# Altium schematic sheet power.SchDoc(power)
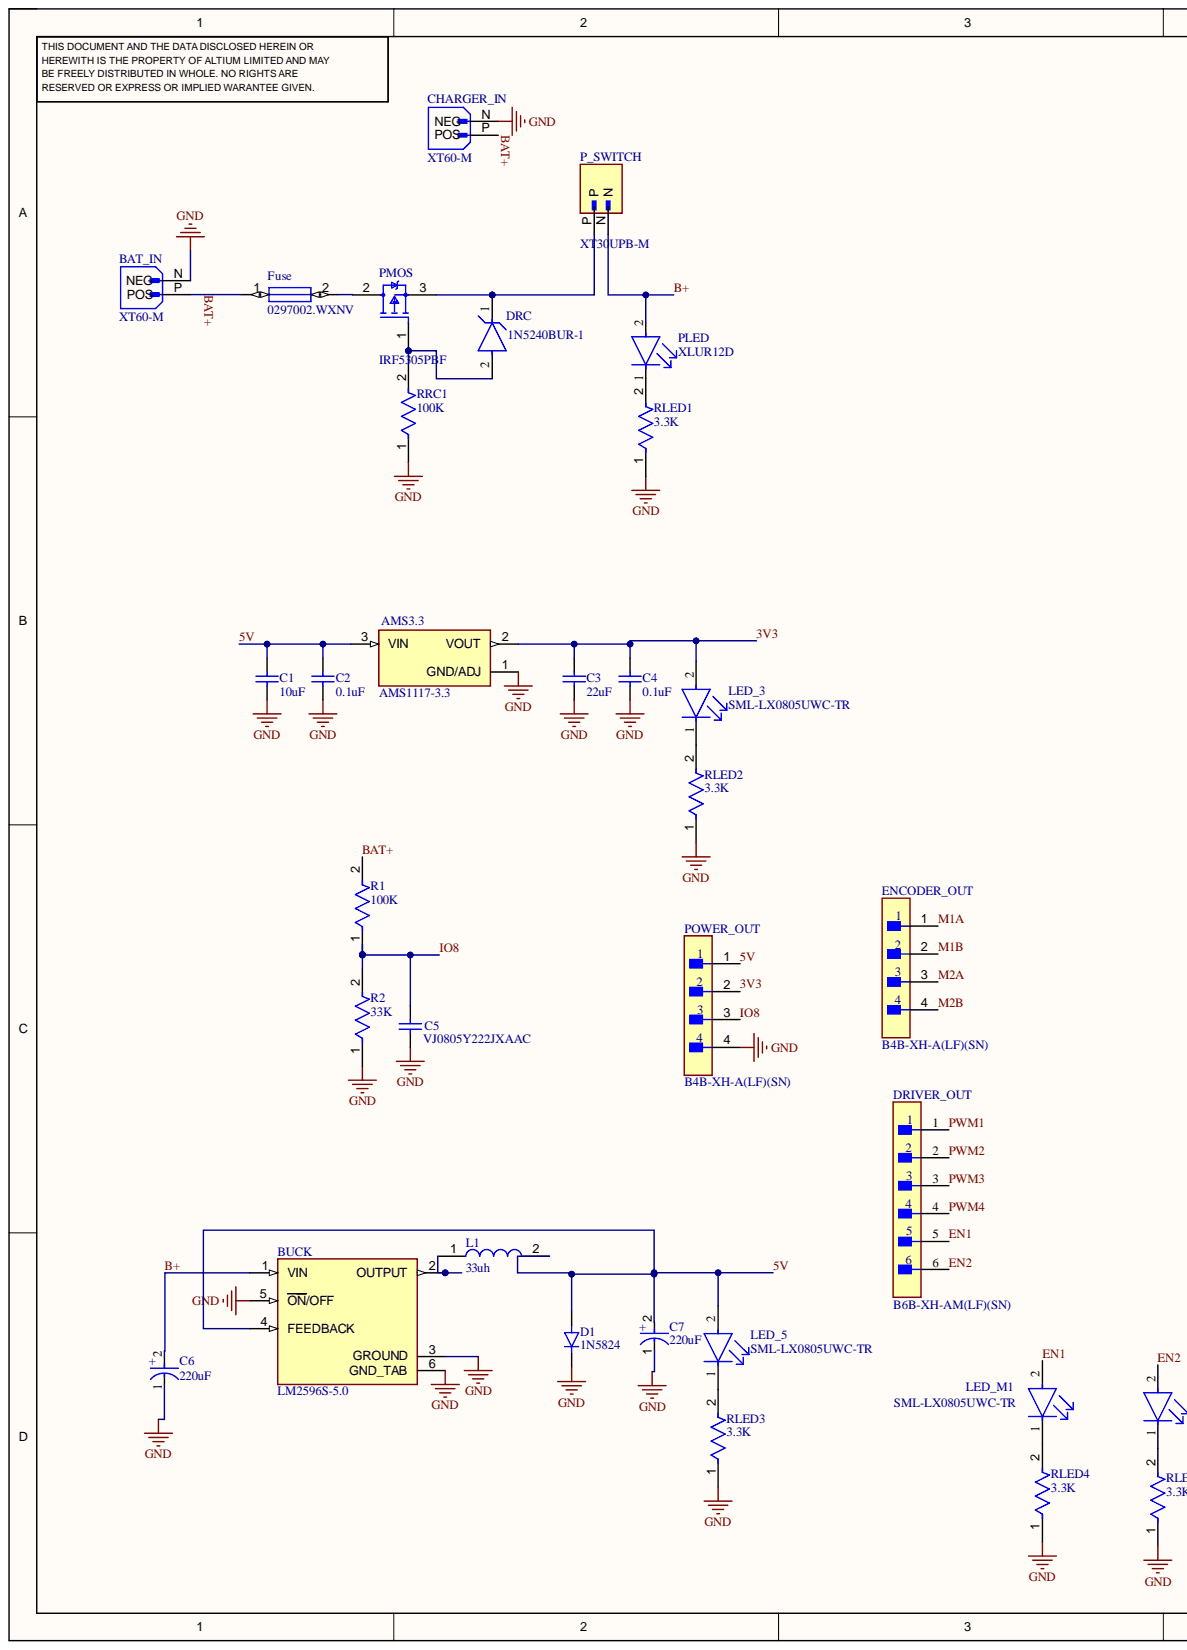
[diagram p0: power.SchDoc, left]
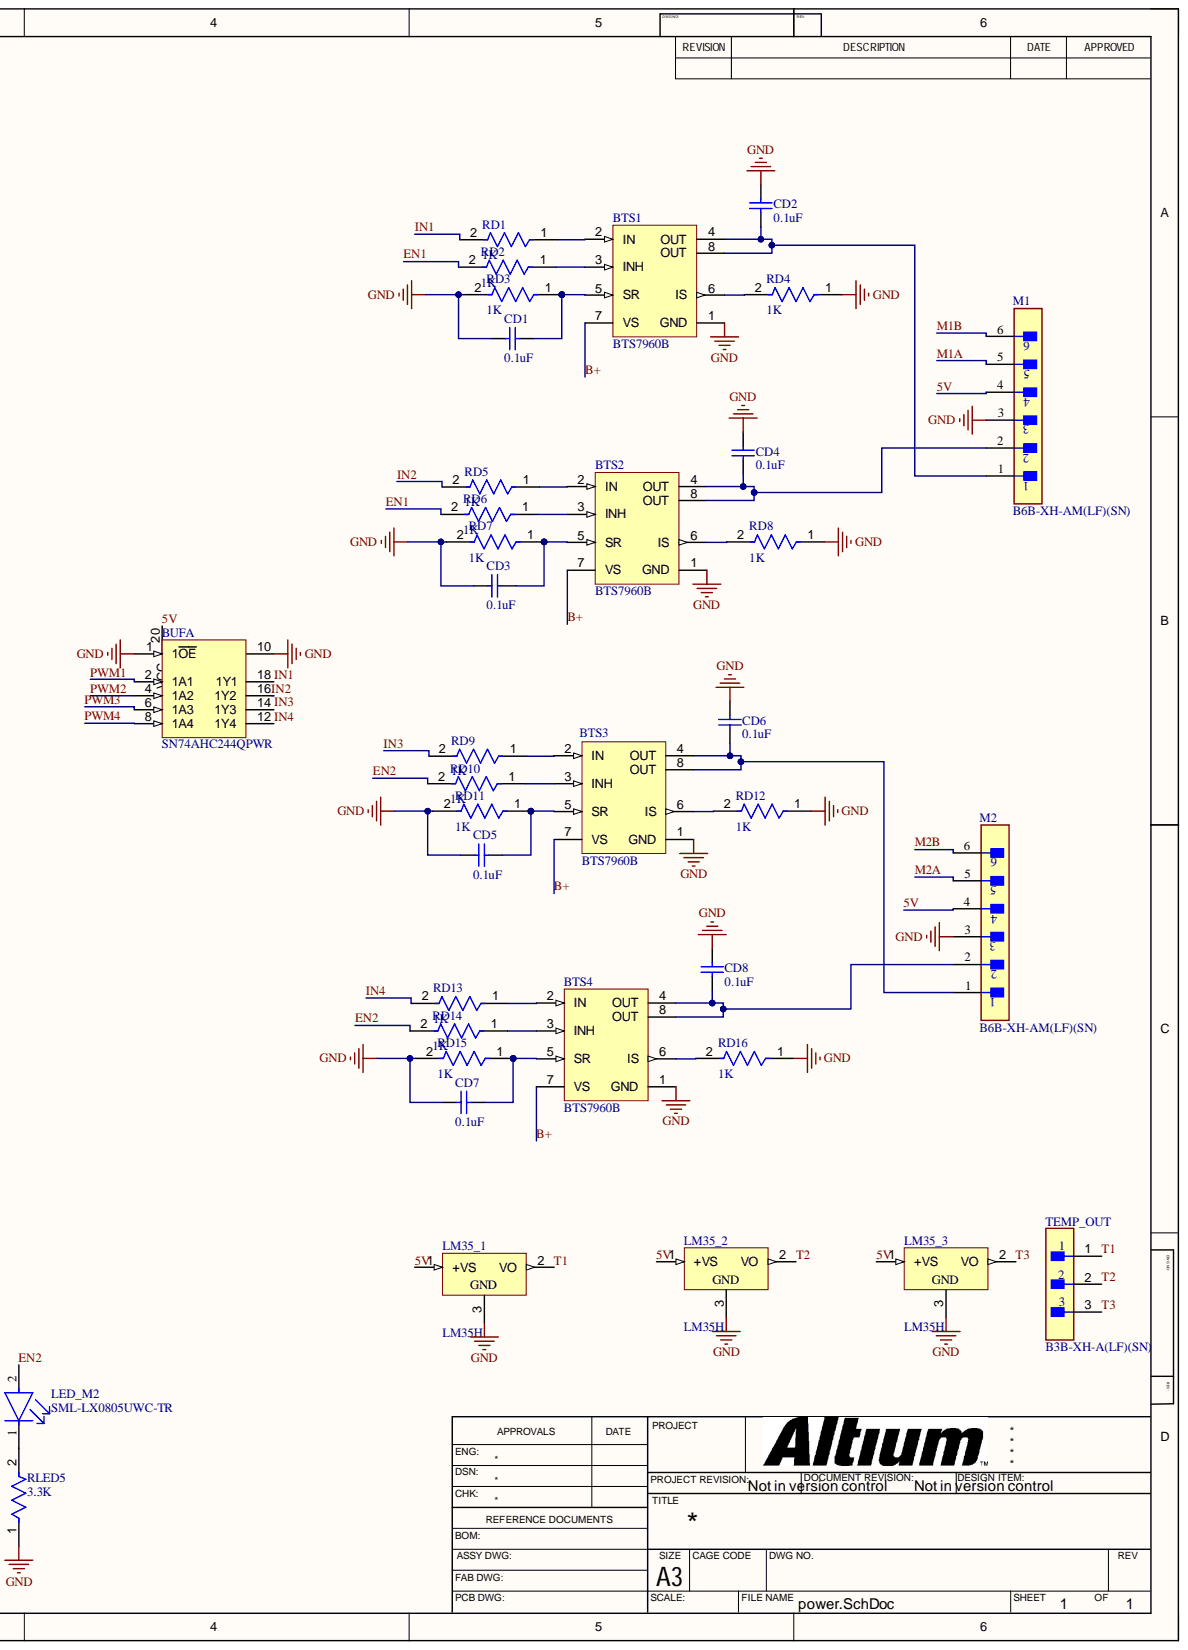
[diagram p1: power.SchDoc, right]

## Parts
AMS3.3  AMS1117-3.3  [SOT229P700X180-4N]
BAT_IN  XT60-M  [AMASS_XT60-M]
BTS1  BTS7960B  [INF-P-TO-263-7-6_M]
BTS2  BTS7960B  [INF-P-TO-263-7-6_M]
BTS3  BTS7960B  [INF-P-TO-263-7-6_M]
BTS4  BTS7960B  [INF-P-TO-263-7-6_M]
BUCK  LM2596S-5.0  [TO170P1524X483-6N]
BUF  SN74AHC244QPWR  [PW0020A_N]  (part A)
C1  10uF  [CAPC2113X140X50LL20T30]
C2  0.1uF  [CAPC2013X145X48NL20T23]
C3  22uF  [CAPC2113X140X50LL20T30]
C4  0.1uF  [CAPC2013X145X48NL20T23]
C5  VJ0805Y222JXAAC  [CAPC2013X145X48NL20T23]
C6  220uF  [FP-107AXZ050M-MFG]
C7  220uF  [FP-EEH-F-MFG]
CD1  0.1uF  [CAPC2013X145X48NL20T23]
CD2  0.1uF  [CAPC2013X145X48NL20T23]
CD3  0.1uF  [CAPC2013X145X48NL20T23]
CD4  0.1uF  [CAPC2013X145X48NL20T23]
CD5  0.1uF  [CAPC2013X145X48NL20T23]
CD6  0.1uF  [CAPC2013X145X48NL20T23]
CD7  0.1uF  [CAPC2013X145X48NL20T23]
CD8  0.1uF  [CAPC2013X145X48NL20T23]
CHARGER_IN  XT60-M  [AMASS_XT60-M]
D1  1N5824  [DIOAD1898W129L762D1143]
DRC  1N5240BUR-1  [FP-DO-213AA-MFG]
DRIVER_OUT  B6B-XH-AM(LF)(SN)  [FP-B6B-XH-AM_LF_SN-MFG]
ENCODER_OUT  B4B-XH-A(LF)(SN)  [FP-B4B-XH-A_LF_SN-MFG]
Fuse  0297002.WXNV  [0297002WXNV]
L1  33uh  [INDPM115100X400N]
LED_3  SML-LX0805UWC-TR  [FP-SML-LX0805UWC-TR-MFG]
LED_5  SML-LX0805UWC-TR  [FP-SML-LX0805UWC-TR-MFG]
LED_M1  SML-LX0805UWC-TR  [FP-SML-LX0805UWC-TR-MFG]
LED_M2  SML-LX0805UWC-TR  [FP-SML-LX0805UWC-TR-MFG]
LM35_1  LM35H  [NDV0003A]
LM35_2  LM35H  [NDV0003A]
LM35_3  LM35H  [NDV0003A]
M1  B6B-XH-AM(LF)(SN)  [FP-B6B-XH-AM_LF_SN-MFG]
M2  B6B-XH-AM(LF)(SN)  [FP-B6B-XH-AM_LF_SN-MFG]
P_SWITCH  XT30UPB-M  [AMASS_XT30UPB-M]
PLED  XLUR12D  [FP-XLUR12D-MFG]
PMOS  IRF5305PBF  [FP-PG-TO220-3-0]
POWER_OUT  B4B-XH-A(LF)(SN)  [FP-B4B-XH-A_LF_SN-MFG]
R1  100K  [FP-RT0805-MFG]
R2  33K  [FP-RT0805-MFG]
RD1  1K  [FP-RT0805-MFG]
RD2  1K  [FP-RT0805-MFG]
RD3  1K  [FP-RT0805-MFG]
RD4  1K  [FP-RT0805-MFG]
RD5  1K  [FP-RT0805-MFG]
RD6  1K  [FP-RT0805-MFG]
RD7  1K  [FP-RT0805-MFG]
RD8  1K  [FP-RT0805-MFG]
RD9  1K  [FP-RT0805-MFG]
RD10  1K  [FP-RT0805-MFG]
RD11  1K  [FP-RT0805-MFG]
RD12  1K  [FP-RT0805-MFG]
RD13  1K  [FP-RT0805-MFG]
RD14  1K  [FP-RT0805-MFG]
RD15  1K  [FP-RT0805-MFG]
RD16  1K  [FP-RT0805-MFG]
RLED1  3.3K  [FP-RT0805-MFG]
RLED2  3.3K  [FP-RT0805-MFG]
RLED3  3.3K  [FP-RT0805-MFG]
RLED4  3.3K  [FP-RT0805-MFG]
RLED5  3.3K  [FP-RT0805-MFG]
RRC1  100K  [FP-PLTU1206-MFG]
TEMP_OUT  B3B-XH-A(LF)(SN)  [FP-B3B-XH-A_LF_SN-MFG]

## Nets
GND: AMS3.3.1 BAT_IN.N BTS1.1(GND) BTS2.1(GND) BTS3.1(GND) BTS4.1(GND) BUCK.3(GROUND) BUCK.5(ON/OFF) BUCK.6(GND_TAB) BUF.1(1OE) BUF.10(GND) C1.1 C2.1 C3.1 C4.1 C5.1 C6.1 C7.1 CD1.2 CD2.2 CD3.2 CD4.2 CD5.2 CD6.2 CD7.2 CD8.2 CHARGER_IN.N D1.C LM35_1.3 LM35_2.3 LM35_3.3 M1.3 M2.3 POWER_OUT.4 R2.1 RD3.2 RD4.1 RD7.2 RD8.1 RD11.2 RD12.1 RD15.2 RD16.1 RLED1.1 RLED2.1 RLED3.1 RLED4.1 RLED5.1 RRC1.1
3V3: AMS3.3.2 C3.2 C4.2 LED_3.2 POWER_OUT.2
5V: AMS3.3.3 BUCK.4(FEEDBACK) BUF.20(VCC) C1.2 C2.2 C7.2 D1.A L1.2 LED_5.2 LM35_1.1 LM35_2.1 LM35_3.1 M1.4 M2.4 POWER_OUT.1
B+: BTS1.7(VS) BTS2.7(VS) BTS3.7(VS) BTS4.7(VS) BUCK.1(VIN) C6.2 P_SWITCH.N PLED.2
BAT+: BAT_IN.P CHARGER_IN.P Fuse.1 R1.2
EN1: DRIVER_OUT.5 LED_M1.2 RD2.2 RD6.2
EN2: DRIVER_OUT.6 LED_M2.2 RD10.2 RD14.2
IN1: BUF.18(1Y1) RD1.2
IN2: BUF.16(1Y2) RD5.2
IN3: BUF.14(1Y3) RD9.2
IN4: BUF.12(1Y4) RD13.2
IO8: C5.2 POWER_OUT.3 R1.1 R2.2
M1A: ENCODER_OUT.1 M1.5
M1B: ENCODER_OUT.2 M1.6
M2A: ENCODER_OUT.3 M2.5
M2B: ENCODER_OUT.4 M2.6
PWM1: BUF.2(1A1) DRIVER_OUT.1
PWM2: BUF.4(1A2) DRIVER_OUT.2
PWM3: BUF.6(1A3) DRIVER_OUT.3
PWM4: BUF.8(1A4) DRIVER_OUT.4
T1: LM35_1.2 TEMP_OUT.1
T2: LM35_2.2 TEMP_OUT.2
T3: LM35_3.2 TEMP_OUT.3
NetBTS1_2: BTS1.2(IN) RD1.1
NetBTS1_3: BTS1.3(INH) RD2.1
NetBTS1_4: BTS1.4(OUT) BTS1.8(OUT) CD2.1 M1.1
NetBTS1_5: BTS1.5(SR) CD1.1 RD3.1
NetBTS1_6: BTS1.6(IS) RD4.2
NetBTS2_2: BTS2.2(IN) RD5.1
NetBTS2_3: BTS2.3(INH) RD6.1
NetBTS2_4: BTS2.4(OUT) BTS2.8(OUT) CD4.1 M1.2
NetBTS2_5: BTS2.5(SR) CD3.1 RD7.1
NetBTS2_6: BTS2.6(IS) RD8.2
NetBTS3_2: BTS3.2(IN) RD9.1
NetBTS3_3: BTS3.3(INH) RD10.1
NetBTS3_4: BTS3.4(OUT) BTS3.8(OUT) CD6.1 M2.1
NetBTS3_5: BTS3.5(SR) CD5.1 RD11.1
NetBTS3_6: BTS3.6(IS) RD12.2
NetBTS4_2: BTS4.2(IN) RD13.1
NetBTS4_3: BTS4.3(INH) RD14.1
NetBTS4_4: BTS4.4(OUT) BTS4.8(OUT) CD8.1 M2.2
NetBTS4_5: BTS4.5(SR) CD7.1 RD15.1
NetBTS4_6: BTS4.6(IS) RD16.2
NetBUCK_2: BUCK.2(OUTPUT) L1.1
NetDRC_1: DRC.1 P_SWITCH.P PMOS.3
NetDRC_2: DRC.2 PMOS.1 RRC1.2
NetFuse_2: Fuse.2 PMOS.2
NetLED_3_1: LED_3.1 RLED2.2
NetLED_5_1: LED_5.1 RLED3.2
NetLED_M1_1: LED_M1.1 RLED4.2
NetLED_M2_1: LED_M2.1 RLED5.2
NetPLED_1: PLED.1 RLED1.2

## Library data of the parts
AMS3.3 = AMS1117-3.3: Sot223/Pkg 1-Amp 3.3-Volt Low Drop Out Voltage Regulatator; MF: Advanced Monolithic Systems; Check_prices: https://www.snapeda.com/parts/AMS1117-3.3/Advanced+Monolithic+Systems/view-part/?ref=eda; Component Kind: Standard; Library Name: AMS1117-3.3.IntLib; MP: AMS1117-3.3; Package: SOT-223 Seeed Technology; SnapEDA_Link: https://www.snapeda.com/parts/AMS1117-3.3/Advanced+Monolithic+Systems/view-part/?ref=snap
BAT_IN CHARGER_IN = XT60-M: Plug; DC supply; XT60; male; PIN: 2; for cable; soldered; 30A; 500V; MF: AMASS; Check_prices: https://www.snapeda.com/parts/XT60-M/AMASS/view-part/?ref=eda; Component Kind: Standard; Library Name: XT60-M.IntLib; MP: XT60-M; Package: Package; SnapEDA_Link: https://www.snapeda.com/parts/XT60-M/AMASS/view-part/?ref=snap
BTS1-BTS4 = CMP-1114-00025-1: High Current PN Half Bridge NovalithIC, -40 to 150 degC, P-TO-263-7-6; Manufacturer: Infineon; Green: FALSE; Iq (typ): 7 uA; Component Kind: Standard; ComponentLink1Description: Manufacturer URL; ComponentLink1URL: http://www.infineon.com/; ComponentLink2Description: Datasheet; ComponentLink2URL: http://www.infineon.com/dgdl/BTS7960_Datasheet.pdf?folderId=db3a304412b407950112b408e8c90004&fileId=db3a304412b407950112b43945006d5d&location=en.Products.Automotive_ICs.Motor_Drivers__Automotive_.Integrated_High_Current_Motor_Drivers__NovalithIC____Trilith; ComponentLink3Description: Package Specification; ComponentLink3URL: http://www.infineon.com/dgdl/BTS7970B_Datasheet.pdf?folderId=db3a304412b407950112b408e8c90004&fileId=db3a304412b407950112b43949b36d61&sId=db3a30433d68e984013d7db637dc5e6a; DatasheetVersion: Rev. 1.1; Halogen-free: FALSE; Library Name: Altium Content Vault; Mounting Technology: Surface Mount; PackageDescription: 7-Pin Plastic Transistor Single Outline Package, 9.9 x 9.2 mm Body, 1.27 mm Pitch; PackageReference: P-TO-263-7-6; PackageVersion: May-06; PartNumber: BTS7960B; RevisionNote: Re-Activated Devices
BUCK = LM2596S-5.0: SIMPLE SWITCHERÂ® 4.5V to 40V, 3A Low Component Count Step-Down Regulator; MF: Texas Instruments; MP: LM2596S-5.0/NOPB; Check_prices: https://www.snapeda.com/parts/LM2596S-5.0/NOPB/Texas+Instruments/view-part/?ref=eda; Component Kind: Standard; Library Name: LM2596S-5.0.IntLib; Package: TO-263-5 Texas Instruments; SnapEDA_Link: https://www.snapeda.com/parts/LM2596S-5.0/NOPB/Texas+Instruments/view-part/?ref=snap
BUF = CMP-0440-01223-3: Automotive Catalog Octal Buffers/Drivers With 3-State Outputs, PW0020A, LARGE T&R; Manufacturer: Texas Instruments; Bits(#): 8; F @ Nom Voltage(Max)(Mhz): 110; ICC @ Nom Voltage(Max)(mA): 0.04; Input Type: CMOS; tpd @ Nom Voltage(Max)(ns): 12.5,8.5; VCC(Max)(V): 5.5; VCC(Min)(V): 2; Voltage(Nom)(V): 3.3,5; Code_JEDEC: MO-153; Component Kind: Standard; DatasheetVersion: SGDS017; Generic Part Number: SN74AHC244Q; Library Name: Altium Content Vault; Operating Temperature Range(C): -40 to 125; Output Type: CMOS; PackageReference: PW0020A; PackageVersion: http://www.ti.com/lit/ml/mpds362/mpds362.pdf; Rating: Catalog
C1 C3 = CMP-2007-04009-1: Manufacturer: AVX Interconnect / Elco; Part Number: TLJR226M010R3800; Component Kind: Standard; Library Name: Altium Content Vault
C2 C4 CD1-CD8 = CMP-2007-01790-1: Manufacturer: Vitramon; Part Number: VJ0805Y222JXAAC; Component Kind: Standard; Library Name: Altium Content Vault
C5 = CMP-2007-01790-1: Manufacturer: Vitramon; Component Kind: Standard; Library Name: Altium Content Vault; Part Number: VJ0805Y222JXAAC
C6 = CMP-29949-000005-1: CAP ALUM 100UF 20% 50V SMD; Component Kind: Standard; Library Name: Altium Content Vault; RoHS Compliant: Yes
C7 = CMP-05428-000570-1: Conductive Polymer Hybrid Aluminum Electrolytic Capacitors 220uF ±20% 25V RADIAL SMT 8x10.2mm; Component Kind: Standard; Library Name: Altium Content Vault; RoHS Compliant: Yes
D1 = 1N5824: Diode Schottky 30V 5A Through Hole Axial; MF: Microsemi Corporation; Availability: Unavailable; Component Kind: Standard; Library Name: 1N5824.IntLib; MP: 1N5824; Package: Axial Microsemi; Price: None; SnapEDA_Link: https://www.snapeda.com/parts/1N5824/Microsemi%20Corporation/view-part/49055/?ref=snap
DRC = CMP-06360-000046-1: DIODE ZENER; Component Kind: Standard; Library Name: Altium Content Vault
DRIVER_OUT M1 M2 = CMP-17439-000167-1: CONN HEADER VERT 6POS 2.5MM; Current Rating: 3A; Mated Stacking Heights: 9.8mm; Number of Poles: 6; Shape: Straight; Voltage Rating: 250V; Component Kind: Standard; Connector Type: Header; Contact Finish - Mating: TIN; Contact Material: Brass; Contact Plating: Copper; Contact Shape: Square; Contact Type: Male Pin; Fastening Type: Detent; Flammability Rating: UL94 V-0; Height: 7mm; Insulation Color: Natural; Insulation Material: Nylon; Length: 17.4mm; Library Name: Altium Content Vault; Max Operating Temperature: 85°C; Min Operating Temperature: -25°C; Mount: Through Hole; Number of Pins: 6; Number of Positions: 6; Number of Rows: 1; Packaging: Bulk; Pitch: 2.5mm; Series: XH; Termination: Press-Fit; Width: 5.75mm
ENCODER_OUT POWER_OUT = CMP-17439-000250-1: CONN HEADER VERT 4POS 2.5MM; Contact Resistance: 20mR; Current Rating: 3A; Gender: Male; Insulation Resistance: 1GR; Mated Stacking Heights: 9.8mm; Max Voltage Rating (AC): 250V; Number of Contacts: 4; Wire/Cable Gauge: 22AWG; Color: Natural; Component Kind: Standard; Connector Type: Header; Contact Finish - Mating: TIN; Contact Material: Brass; Contact Plating: Tin; Contact Shape: Square; Contact Type: Male Pin; Depth: 5.75mm; Fastening Type: Detent; Flammability Rating: UL94 V-0; Housing Color: White; Housing Material: Polyamide; Insulation Color: Natural; Insulation Material: Nylon; Lead Free: Lead Free; Lead Pitch: 2.5mm; Length: 12.4mm; Library Name: Altium Content Vault; Material: Polyamide; Max Current Rating: 3A; Max Operating Temperature: 85°C; Max Voltage Rating (DC): 250V; Min Operating Temperature: -25°C; Mount: Through Hole; Number of Positions: 4; Number of Rows: 1; Orientation: Straight; Package Quantity: 500; Packaging: Bulk; Pitch: 2.5mm; REACH SVHC: No SVHC; Series: XH; Termination: Solder; Voltage Rating: 250V; Voltage Rating (AC): 250V
Fuse = 0297002.WXNV: 2A AC 32V DC Fuse Automotive Holder Blade, Miniature; MF: Littelfuse Inc.; Availability: Good; Check_prices: https://www.snapeda.com/parts/0297002.WXNV/Littelfuse%20Inc./view-part/289173/?ref=eda; Component Kind: Standard; Library Name: 0297002.WXNV.IntLib; MP: 0297002.WXNV; Package: Blade Miniature  Littelfuse; Price: None; Purchase-URL: https://pricing.snapeda.com/search/part/0297002.WXNV/?ref=eda; SnapEDA_Link: https://www.snapeda.com/parts/0297002.WXNV/Littelfuse%20Inc./view-part/289173/?ref=snap
L1 = SMMS1040-330M: Inductor; Manufacturer_Name: Shun Xiang Nuo Elec; Component Kind: Standard; Datasheet Link: https://www.lcsc.com/datasheet/lcsc_datasheet_2108131730_SXN-Shun-Xiang-Nuo-Elec-SMMS1040-330M_C149598.pdf; Height: 4mm; Manufacturer_Part_Number: SMMS1040-330M
LED_3 LED_5 LED_M1 LED_M2 = CMP-00229-00139794-1: SML-LX0805UWC-TR White LED, 0805 Diffused, Rectangle Lens SMD package; NexarPartId: 139794; Approvals: CSA, UL; Forward Current: 20mA; Forward Voltage: 3.5V; Lens Color: Clear, Yellow; Luminous Intensity: 150mcd; Number of LEDs: 1; Power Rating: 120mW; Viewing Angle: 120°; Case/Package: 0805; Component Kind: Standard; Illumination Color: White; Library Name: Altium Content Vault; Max Operating Temperature: 85°C; Min Operating Temperature: -40°C; Mount: Surface Mount; RoHS: Compliant
LM35_1-LM35_3 = CMP-0066-00004-3: Precision Centigrade Temperature Sensor, 3-pin TO-46; Manufacturer: Texas Instruments; CompType: Temp Sensor IC; PackageTopMarking: NSXYTTE# LM35H; PowerWise: N; Code_JEDEC: TO-46; Component Kind: Standard; ComponentLink1Description: Datasheet URL; ComponentLink1URL: http://www.ti.com/general/docs/lit/getliterature.tsp?genericPartNumber=LM35&fileType=pdf; Library Name: Altium Content Vault; PackageDescription: Metal Can, TO-46, 3 Lead, .100in Dia, P.C.; PackageReference: NDV0003A; PinCount: 3; RoHS: N
P_SWITCH = XT30UPB-M: Socket; DC supply; XT30; male; PIN: 2; on PCBs; THT; yellow; 15A; 500V; MF: amass; Check_prices: https://www.snapeda.com/parts/XT30UPB-M/AMASS/view-part/?ref=eda; Component Kind: Standard; Library Name: XT30UPB-M.IntLib; MP: XT30UPB-M; Package: None; SnapEDA_Link: https://www.snapeda.com/parts/XT30UPB-M/AMASS/view-part/?ref=snap
PLED = CMP-02085-08376351-1: 627nm Red LED; 5x8.6mm, Through-Hole Mount with Red Diffused Round Lamp; Dominant Wavelength: 617nm; Forward Voltage: 1.9V; Luminous Intensity: 39mcd; Test Current: 10mA; Viewing Angle: 30°; Case/Package: Radial; Color: Red; Component Kind: Standard; Height: 8.6mm; Lens Color: Red; Lens Transparency: Diffused; Library Name: Altium Content Vault; Lifecycle Status: Production; Mount: Through Hole; RoHS: Compliant; Wavelength: 627nm
PMOS = CMP-32901-000003-1: MOSFET P-CH 55V 31A TO-220AB; Manufacturer: Infineon; Continuous Drain Current: -31A; Depletion Mode: False; Drain To Source Breakdown Voltage: -55V; Drain To Source Resistance: 0.06Ω; Gate Charge At Vgs: 63nC @ -10V; Gate To Source Voltage: 20V; Input Capacitace At Vds: 1200pF @ -25V; Max Forward Diode Voltage: -1.3V; Max Junction Temp: +175°C; Number Of Channels: 1; Power Dissipation: 110W; Pulse Drain Current: -110A; Reverse Recovery Charge: 170nC; Reverse Recovery Time: 71ns; Rthja Max: 62°C/W; Standoff Height: 3.55mm; Threshold Vgs Max: -4V; Threshold Vgs Min: -2V; Turn Off Delay Time: 39ns; Turn On Delay Time: 14ns; Automotive: No; Category: Trans; Component Kind: Standard; ComponentLink2Description: Datasheet; ComponentLink2URL: https://www.infineon.com/dgdl/irf5305pbf.pdf?fileId=5546d462533600a4015355e370101993; Device Class L1: Discrete Semiconductors; Device Class L2: Transistors; Device Class L3: MOSFETs; Digikey Description: MOSFET P-CH 55V 31A TO-220AB; Drain To Source Voltage: -55V; Height: 19.3mm; Lead Free: Yes; Library Name: Altium Content Vault; Manufacturer Part Number: IRF5305PBF; Number Of P Channels: 1; Octopart: https://octopart.com/irf5305pbf-infineon-65873396; Package: PG-TO220-3; RoHS: TRUE; Temperature: -55°C to +175°C; Temperature Range High: +175°C; Temperature Range Low: -55°C
R1 R2 RD1-RD16 RLED1-RLED5 = CMP-03412-000032-1: RES SMD 5.1 OHM 0.1% 1/8W 0805; Manufacturer: Yageo; Manufacturer Part Number: RT0805BRD075R1L; Power Rating: 0.125W; Resistance: 5.1Ω; Temperature Coefficient: 25 ppm/°C; Tolerance: 0.0025; Value: 5.1Ω; Voltage Rating: 150V; Automotive: No; Category: Res; Component Kind: Standard; ComponentLink2Description: Datasheet; ComponentLink2URL: http://www.yageo.com/documents/recent/PYu-RT_1-to-0.01_RoHS_L_9.pdf; Composition: Thin Film; Device Class L1: Passive Components; Device Class L2: Resistors; Device Class L3: Chip SMD Resistors; Digikey Description: RES SMD 5.1 OHM 0.1% 1/8W 0805; Footprint Url: http://www.yageo.com/exep/pages/download/literatures/PYu-R_Mount_10.pdf; Height: 0.6mm; Ipc Land Pattern Name: RESC200125X50; Lead Free: Yes; Library Name: Altium Content Vault; Octopart: https://octopart.com/rt0805brd075r1l-yageo-30569191; Package: RT0805; RoHS: TRUE; Series: RT; Temperature: -55°C to +155°C; Temperature Range High: +155°C; Temperature Range Low: -55°C
RRC1 = CMP-02407-012706-1: RES SMD 100K OHM 0.01% 0.4W 1206; Max Power Dissipation: 400mW; Resistance: 100kR; Temperature Coefficient: 2ppm/°C; Case Code (Imperial): 1206; Case Code (Metric): 3016; Case/Package: 1206; Component Kind: Standard; Features: Moisture Resistant; Height: 0.033inch; Length: 0.126inch; Library Name: Altium Content Vault; Max Operating Temperature: 125°C; Min Operating Temperature: -55°C; Number of Terminations: 2; Packaging: Tape and Reel; Resistor Type: Thin Film; Tolerance: 0.01%; Width: 0.063inch
TEMP_OUT = CMP-17439-000014-3: CONN HEADER VERT 3POS 2.5MM; Contact Resistance: 20mR; Current Rating: 3A; Gender: Male; Insulation Resistance: 1GR; Max Voltage Rating (AC): 250V; Number of Contacts: 3; Wire/Cable Gauge: 22AWG; Color: Natural; Component Kind: Standard; Connector Type: Header; Contact Finish - Mating: TIN; Contact Material: Brass; Contact Plating: Tin; Contact Shape: Square; Contact Type: Male Pin; Depth: 5.75mm; Fastening Type: Detent; Flammability Rating: UL94 V-0; Housing Color: White; Housing Material: Polyamide; Insulation Color: Natural; Insulation Material: Nylon; Lead Free: Lead Free; Lead Pitch: 2.5mm; Length: 9.9mm; Library Name: Altium Content Vault; Max Current Rating: 3A; Max Operating Temperature: 85°C; Max Voltage Rating (DC): 250V; Min Operating Temperature: -25°C; Mount: Through Hole; Number of Positions: 3; Number of Rows: 1; Orientation: Straight; Packaging: Bulk; Pitch: 2.5mm; REACH SVHC: No SVHC; Series: XH; Termination: Solder; Voltage Rating: 250V; Voltage Rating (AC): 250V
